# Altium schematic sheet Airlock_BTLE.SchDoc(Airlock_BTLE)
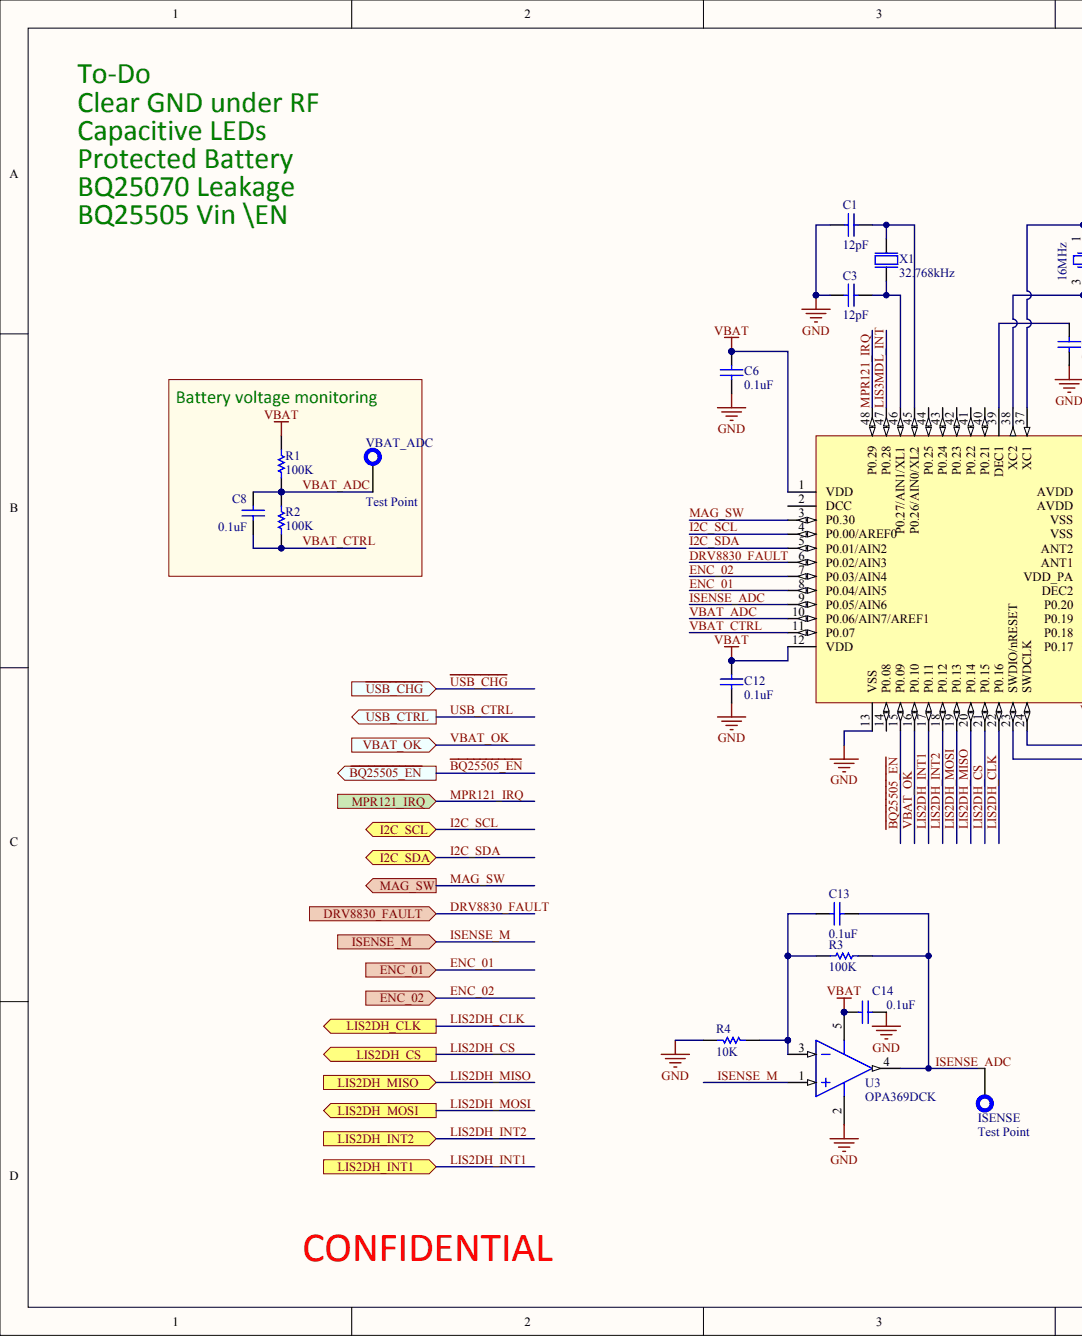
[diagram p0: Airlock_BTLE.SchDoc, left]
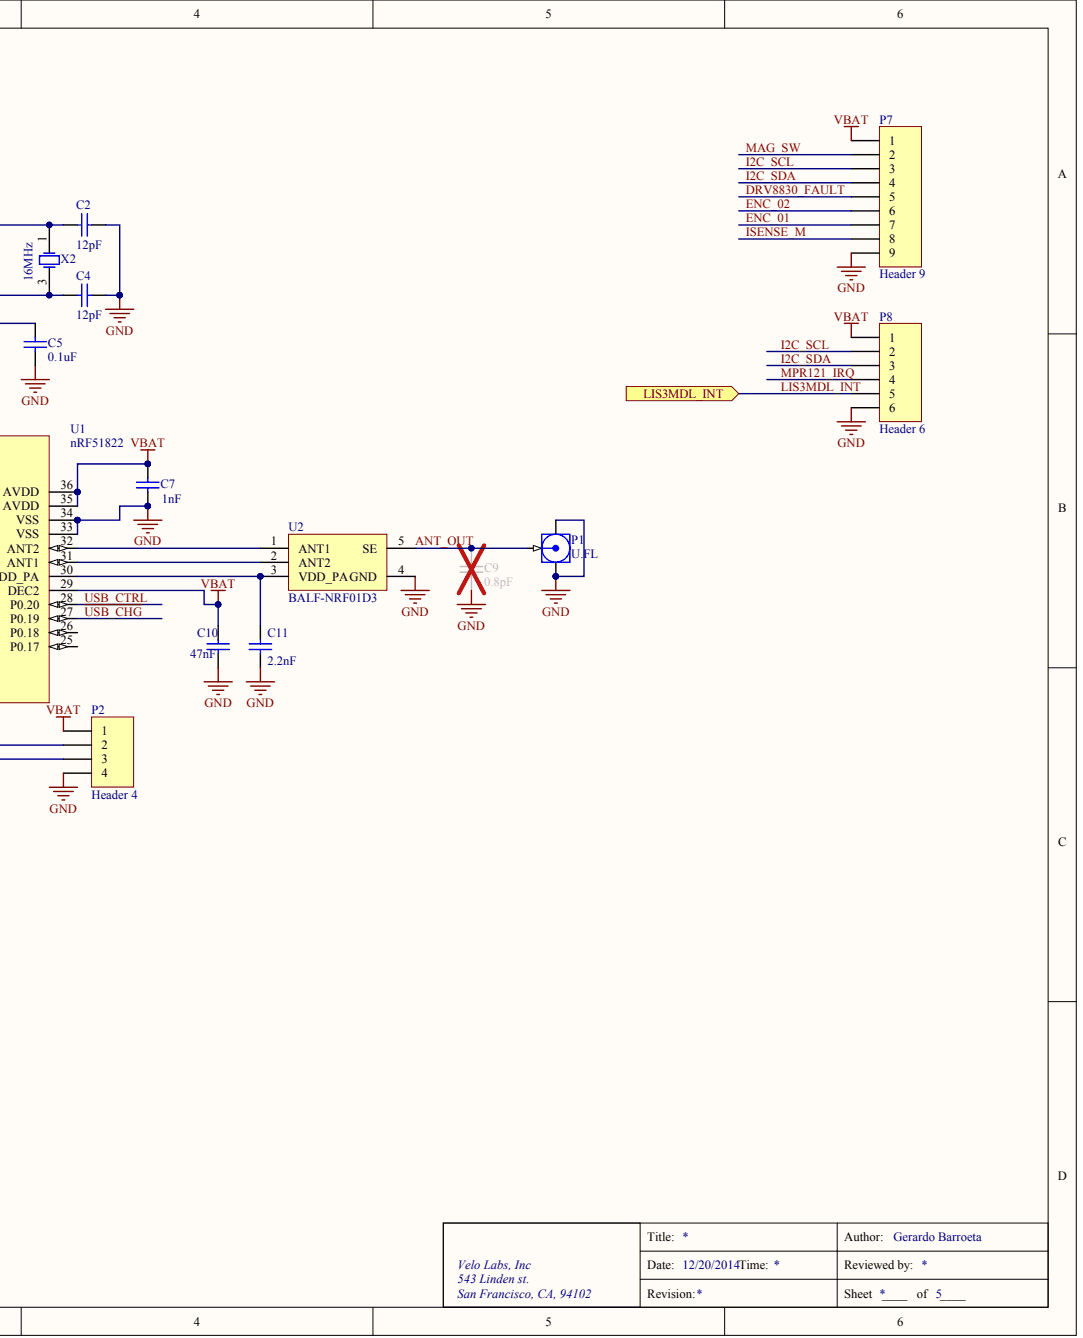
[diagram p1: Airlock_BTLE.SchDoc, right]

## Parts
C1  value 12pF  (hidden comment: 1% NP0, 50V)
C2  value 12pF  (hidden comment: 1% NP0, 50V)
C3  value 12pF  (hidden comment: 1% NP0, 50V)
C4  value 12pF  (hidden comment: 1% NP0, 50V)
C5  value 0.1uF  (hidden comment: X7R, 16V, 10%)
C6  value 0.1uF  (hidden comment: X7R, 16V, 10%)
C7  value 1nF  (hidden comment: 1%, NP0, 50V)
C8  value 0.1uF  (hidden comment: X7R, 16V, 10%)
C9  value 0.8pF  (hidden comment: Cap)
C10  value 47nF  (hidden comment: 10%, X7R, 25V)
C11  value 2.2nF  (hidden comment: X7R, 50V, 10%)
C12  value 0.1uF  (hidden comment: X7R, 16V, 10%)
C13  value 0.1uF  (hidden comment: X7R, 16V, 10%)
C14  value 0.1uF  (hidden comment: X7R, 16V, 10%)
ISENSE  Test Point
P1  U.FL
P2  Header 4
P7  Header 9
P8  Header 6
R1  value 100K  (hidden comment: 5% 1/10W)
R2  value 100K  (hidden comment: 5% 1/10W)
R3  value 100K  (hidden comment: 5% 1/10W)
R4  1%  value 10K
U1  nRF51822
U2  BALF-NRF01D3
U3  OPA369DCK
VBAT_ADC  Test Point
X1  32.768kHz
X2  Load Capacitance: 12pF  (hidden comment: 16MHz)

## Ports
DRV8830_FAULT, ENC_01, ENC_02, I2C_SCL, I2C_SDA, ISENSE_M, LIS2DH_CLK, LIS2DH_CS, LIS2DH_INT1, LIS2DH_INT2, LIS2DH_MISO, LIS2DH_MOSI, LIS3MDL_INT, MAG_SW, MPR121_IRQ, USB_CTRL, VBAT_OK, ~{BQ25505_EN}, ~{USB_CHG}

## Nets
GND: C1.1 C2.1 C3.1 C4.1 C5.1 C6.1 C7.1 C9.1 C10.1 C11.1 C12.1 C14.2 P1.2 P1.3 P2.4 P7.9 P8.6 R4.2 U1.13(VSS) U1.33(VSS) U1.34(VSS) U1.49 U2.4(GND) U3.2 X2.2 X2.4
VBAT: C6.2 C7.2 C10.2 C12.2 C14.1 P2.1 P7.1 P8.1 R1.2 U1.1(VDD) U1.12(VDD) U1.29(DEC2) U1.35(AVDD) U1.36(AVDD) U3.5
~{BQ25505_EN}: U1.15(P0.09) ; port ~{BQ25505_EN}
~{USB_CHG}: U1.27(P0.19) ; port ~{USB_CHG}
ANT_OUT: C9.2 P1.1 U2.5(SE)
DRV8830_FAULT: P7.5 U1.6(P0.02/AIN3) ; port DRV8830_FAULT
ENC_01: P7.7 U1.8(P0.04/AIN5) ; port ENC_01
ENC_02: P7.6 U1.7(P0.03/AIN4) ; port ENC_02
I2C_SCL: P7.3 P8.2 U1.4(P0.00/AREF0) ; port I2C_SCL
I2C_SDA: P7.4 P8.3 U1.5(P0.01/AIN2) ; port I2C_SDA
ISENSE_ADC: C13.2 ISENSE.1 R3.1 U1.9(P0.05/AIN6) U3.4
ISENSE_M: P7.8 U3.1 ; port ISENSE_M
LIS2DH_CLK: U1.22(P0.16) ; port LIS2DH_CLK
LIS2DH_CS: U1.21(P0.15) ; port LIS2DH_CS
LIS2DH_INT1: U1.17(P0.11) ; port LIS2DH_INT1
LIS2DH_INT2: U1.18(P0.12) ; port LIS2DH_INT2
LIS2DH_MISO: U1.20(P0.14) ; port LIS2DH_MISO
LIS2DH_MOSI: U1.19(P0.13) ; port LIS2DH_MOSI
LIS3MDL_INT: P8.5 U1.47(P0.28) ; port LIS3MDL_INT
MAG_SW: P7.2 U1.3(P0.30) ; port MAG_SW
MPR121_IRQ: P8.4 U1.48(P0.29) ; port MPR121_IRQ
USB_CTRL: U1.28(P0.20) ; port USB_CTRL
VBAT_ADC: C8.2 R1.1 R2.2 U1.10(P0.06/AIN7/AREF1) VBAT_ADC.1
VBAT_CTRL: C8.1 R2.1 U1.11(P0.07)
VBAT_OK: U1.16(P0.10) ; port VBAT_OK
NetC1_2: C1.2 U1.45(P0.26/AIN0/XL2) X1.1
NetC2_2: C2.2 U1.37(XC1) X2.1
NetC3_2: C3.2 U1.46(P0.27/AIN1/XL1) X1.2
NetC4_2: C4.2 U1.38(XC2) X2.3
NetC5_2: C5.2 U1.39(DEC1)
NetC11_2: C11.2 U1.30(VDD_PA) U2.3(VDD_PA)
NetC13_1: C13.1 R3.2 R4.1 U3.3
NetP2_2: P2.2 U1.24(SWDCLK)
NetP2_3: P2.3 U1.23(SWDIO/nRESET)
NetU1_31: U1.31(ANT1) U2.2(ANT2)
NetU1_32: U1.32(ANT2) U2.1(ANT1)

Not connected: U1 2(DCC) 14(P0.08) 25(P0.17) 26(P0.18) 40(P0.21) 41(P0.22) 42(P0.23) 43(P0.24) 44(P0.25)

## Library data of the parts
C1 C3 C4 = (no symbol name): Manufacturer: Murata Electronics North America; Manufacturer Part Number: GRM1555C1H120FA01D; Description 1: CAP CER 12PF 50V 1% NP0 0402; Temperature Coefficient: C0G, NP0; Supplier 1: Digi-Key; Supplier Part Number 1: 490-6196-1-ND; RoHS: RoHS Compliant; Category: Capacitors; Stock 1: 92208; Pricing 1: 1=0.1, 10=0.054, 50=0.0292, 100=0.0247, 250=0.0202, 500=0.01728, 1000=0.0132, 5000=0.011 (USD); Packaging: Cut Tape (CT); Capacitance: 12pF; Voltage - Rated: 50V; Tolerance: ±1%; Mounting Type: Surface Mount, MLCC; Operating Temperature: -55°C ~ 125°C; Applications: General Purpose; Ratings: -; Package / Case: 0402 (1005 Metric); Size / Dimension: 0.039" L x 0.020" W (1.00mm x 0.50mm); Height - Seated (Max): -; Thickness (Max): 0.022" (0.55mm); Lead Spacing: -; Features: -; Lead Style: -; ComponentLink1URL: http://search.murata.co.jp/Ceramy/image/img/A01X/G101/ENG/GRM1555C1H120FA01-01.pdf; ComponentLink1Description: http://search.murata.co.jp/Ceramy/image/img/A01X/G101/ENG/GRM1555C1H120FA01-01.pdf
C2 = (no symbol name): Manufacturer: Murata Electronics North America; Manufacturer Part Number: GRM1555C1H120FA01D; Value: 12pF; Description 1: CAP CER 12PF 50V 1% NP0 0402; Temperature Coefficient: C0G, NP0; Supplier 1: Digi-Key; Supplier Part Number 1: 490-6196-1-ND; RoHS: RoHS Compliant; Category: Capacitors; Stock 1: 92208; Pricing 1: 1=0.1, 10=0.054, 50=0.0292, 100=0.0247, 250=0.0202, 500=0.01728, 1000=0.0132, 5000=0.011 (USD); Packaging: Cut Tape (CT); Capacitance: 12pF; Voltage - Rated: 50V; Tolerance: ±1%; Mounting Type: Surface Mount, MLCC; Operating Temperature: -55°C ~ 125°C; Applications: General Purpose; Ratings: -; Package / Case: 0402 (1005 Metric); Size / Dimension: 0.039" L x 0.020" W (1.00mm x 0.50mm); Height - Seated (Max): -; Thickness (Max): 0.022" (0.55mm); Lead Spacing: -; Features: -; Lead Style: -; ComponentLink1URL: http://search.murata.co.jp/Ceramy/image/img/A01X/G101/ENG/GRM1555C1H120FA01-01.pdf; ComponentLink1Description: http://search.murata.co.jp/Ceramy/image/img/A01X/G101/ENG/GRM1555C1H120FA01-01.pdf
C5 C6 C8 C12-C14 = (no symbol name): Manufacturer: Murata Electronics North America; Manufacturer Part Number: GRM155R71C104KA88D; Description 1: CAP CER 0.1UF 16V 10% X7R 0402; Capacitance: 0.1µF; Supplier 1: Digi-Key; Supplier Part Number 1: 490-3261-1-ND; RoHS: RoHS Compliant; Category: Capacitors; Stock 1: 16410340; Pricing 1: 1=0.1, 10=0.015, 50=0.0082, 100=0.0069, 250=0.00564, 500=0.00482, 1000=0.00375, 5000=0.00313 (USD); Packaging: Cut Tape (CT); Tolerance: ±10%; Voltage - Rated: 16V; Temperature Coefficient: X7R; Mounting Type: Surface Mount, MLCC; Operating Temperature: -55°C ~ 125°C; Applications: General Purpose; Ratings: -; Package / Case: 0402 (1005 Metric); Size / Dimension: 0.039" L x 0.020" W (1.00mm x 0.50mm); Height - Seated (Max): -; Thickness (Max): 0.022" (0.55mm); Lead Spacing: -; Features: -; Lead Style: -; ComponentLink1URL: http://www.murata.com/~/media/webrenewal/support/library/catalog/products/capacitor/mlcc/c02e.ashx; ComponentLink1Description: http://www.murata.com/~/media/webrenewal/support/library/catalog/products/capacitor/mlcc/c02e.ashx
C7 = (no symbol name): Manufacturer: Murata Electronics North America; Manufacturer Part Number: GRM1555C1H102FA01D; Description 1: CAP CER 1000PF 50V 1% NP0 0402; Capacitance: 1000pF; Temperature Coefficient: C0G, NP0; Published: 8-Jun-2000; LatestRevisionDate: 17-Jul-2002; LatestRevisionNote: Re-released for DXP Platform.; PackageReference: RAD-0.3; PackageDescription: Radial Cap, Thru-Hole; 2 Leads; 0.3 in Pin Spacing; Supplier 1: Digi-Key; Supplier Part Number 1: 490-6189-1-ND; RoHS: RoHS Compliant; Category: Capacitors; Stock 1: 28524; Pricing 1: 1=0.22, 10=0.147, 50=0.089, 100=0.0748, 250=0.06052, 500=0.0534, 1000=0.04539, 5000=0.03827 (USD); Packaging: Cut Tape (CT); Voltage - Rated: 50V; Tolerance: ±1%; Mounting Type: Surface Mount, MLCC; Operating Temperature: -55°C ~ 125°C; Applications: General Purpose; Ratings: -; Package / Case: 0402 (1005 Metric); Size / Dimension: 0.039" L x 0.020" W (1.00mm x 0.50mm); Height - Seated (Max): -; Thickness (Max): 0.022" (0.55mm); Lead Spacing: -; Features: -; Lead Style: -; ComponentLink1URL: http://search.murata.co.jp/Ceramy/image/img/A01X/G101/ENG/GRM1555C1H102FA01-01.pdf; ComponentLink1Description: http://search.murata.co.jp/Ceramy/image/img/A01X/G101/ENG/GRM1555C1H102FA01-01.pdf; Publisher: Altium Limited
C10 = (no symbol name): Manufacturer: Murata Electronics North America; Manufacturer Part Number: GRM155R71E473KA88D; Description 1: CAP CER 0.047UF 25V 10% X7R 0402; Capacitance: 0.047µF; Published: 8-Jun-2000; LatestRevisionDate: 17-Jul-2002; LatestRevisionNote: Re-released for DXP Platform.; PackageReference: RAD-0.3; PackageDescription: Radial Cap, Thru-Hole; 2 Leads; 0.3 in Pin Spacing; Supplier 1: Digi-Key; Supplier Part Number 1: 490-3254-2-ND; RoHS: RoHS Compliant; Category: Capacitors; Stock 1: 110000; Pricing 1: 10000=0.0051, 20000=0.00486, 30000=0.00462, 50000=0.00413, 100000=0.00334 (USD); Packaging: Tape & Reel (TR); Voltage - Rated: 25V; Tolerance: ±10%; Temperature Coefficient: X7R; Mounting Type: Surface Mount, MLCC; Operating Temperature: -55°C ~ 125°C; Applications: General Purpose; Ratings: -; Package / Case: 0402 (1005 Metric); Size / Dimension: 0.039" L x 0.020" W (1.00mm x 0.50mm); Height - Seated (Max): -; Thickness (Max): 0.022" (0.55mm); Lead Spacing: -; Features: -; Lead Style: -; ComponentLink1URL: http://www.murata.com/products/catalog/pdf/c02e.pdf; ComponentLink1Description: http://www.murata.com/products/catalog/pdf/c02e.pdf; Publisher: Altium Limited
C11 = (no symbol name): Manufacturer: Murata Electronics North America; Manufacturer Part Number: GRM155R71H222KA01D; Description 1: CAP CER 2200PF 50V 10% X7R 0402; Capacitance: 2200pF; Published: 8-Jun-2000; LatestRevisionDate: 29-May-2009; LatestRevisionNote: IPC-7351 Footprint Added.; PackageReference: C1206; PackageDescription: Chip Capacitor; Supplier 1: Digi-Key; Supplier Part Number 1: 490-1305-1-ND; RoHS: RoHS Compliant; Category: Capacitors; Stock 1: 342279; Pricing 1: 1=0.1, 10=0.021, 50=0.0114, 100=0.0096, 250=0.00788, 500=0.00674, 1000=0.00525, 5000=0.00438 (USD); Packaging: Cut Tape (CT); Voltage - Rated: 50V; Tolerance: ±10%; Temperature Coefficient: X7R; Mounting Type: Surface Mount, MLCC; Operating Temperature: -55°C ~ 125°C; Applications: General Purpose; Ratings: -; Package / Case: 0402 (1005 Metric); Size / Dimension: 0.039" L x 0.020" W (1.00mm x 0.50mm); Height - Seated (Max): -; Thickness (Max): 0.022" (0.55mm); Lead Spacing: -; Features: -; Lead Style: -; ComponentLink1URL: http://www.murata.com/products/catalog/pdf/c02e.pdf; ComponentLink1Description: http://www.murata.com/products/catalog/pdf/c02e.pdf; Publisher: Altium Limited
P1 = (no symbol name): Manufacturer: Hirose Electric Co Ltd; Manufacturer Part Number: U.FL-R-SMT(10); Description 1: CONN UMC JACK STR 50 OHM SMD; Connector Style: Ultra Miniature Coaxial; Connector Type: Jack, Male Pin; Contact Termination: Solder; Impedance: 50 Ohm; Frequency - Max: 6GHz; Body Material: Phosphor Bronze; Body Finish: Silver; Center Contact Plating: Gold; Dielectric Material: Liquid Crystal Polymer (LCP); Supplier 1: Digi-Key; RoHS: RoHS Compliant; Category: Connectors, Interconnects; Stock 1: 135430; Pricing 1: 1=1.31, 10=1.15, 25=1.0824, 50=1.0374, 100=0.9922, 250=0.902, 500=0.8118, 1000=0.7216 (USD); Packaging: Cut Tape (CT); Shield Termination: Solder; Mounting Type: Surface Mount; Cable Group: -; Fastening Type: Snap-On; Features: -; Housing Color: Silver; Ingress Protection: -; Center Contact Material: Phosphor Bronze; Voltage Rating: -; Operating Temperature: -40°C ~ 90°C; ComponentLink1URL: http://www.hirose.co.jp/cataloge_hp/e32119372.pdf; ComponentLink1Description: http://www.hirose.co.jp/cataloge_hp/e32119372.pdf; Supplier Part Number 1: H9161CT-ND
R1-R3 = (no symbol name): Manufacturer: Panasonic Electronic Components; Manufacturer Part Number: ERJ-2GEJ104X; Description 1: RES 100K OHM 1/10W 5% 0402 SMD; Power (Watts): 0.1W, 1/10W; Temperature Coefficient: ±200ppm/°C; Published: 8-Jun-2000; LatestRevisionDate: 17-Jul-2002; LatestRevisionNote: Re-released for DXP Platform.; PackageReference: AXIAL-0.3; PackageDescription: Axial Device, Thru-Hole; 2 Leads; 0.3 in Pin Spacing; Supplier 1: Digi-Key; Supplier Part Number 1: P100KJCT-ND; RoHS: RoHS Compliant; Category: Resistors; Stock 1: 539799; Pricing 1: 1=0.1, 50=0.0172, 100=0.0131, 250=0.00976, 500=0.0072, 1000=0.0048, 5000=0.00338 (USD); Packaging: Cut Tape (CT); Resistance (Ohms): 100k; Tolerance: ±5%; Composition: Thick Film; Features: -; Package / Case: 0402 (1005 Metric); Supplier Device Package: 0402; Size / Dimension: 0.039" L x 0.020" W (1.00mm x 0.50mm); Height: 0.016" (0.40mm); Number of Terminations: 2; ComponentLink1URL: http://industrial.panasonic.com/www-cgi/jvcr13pz.cgi?E+PZ+3+AOA0001+ERJ2GEJ104X+7+WW; ComponentLink1Description: http://industrial.panasonic.com/www-cgi/jvcr13pz.cgi?E+PZ+3+AOA0001+ERJ2GEJ104X+7+WW; Publisher: Altium Limited
R4 = (no symbol name): Manufacturer: Panasonic Electronic Components; Manufacturer Part Number: ERJ-2RKF1002X; Description 1: RES SMD 10K OHM 1% 1/10W 0402; Power (Watts): 0.1W, 1/10W; Temperature Coefficient: ±100ppm/°C; Published: 8-Jun-2000; LatestRevisionDate: 17-Jul-2002; LatestRevisionNote: Re-released for DXP Platform.; PackageReference: AXIAL-0.3; PackageDescription: Axial Device, Thru-Hole; 2 Leads; 0.3 in Pin Spacing; Supplier 1: Digi-Key; Supplier Part Number 1: P10.0KLCT-ND; RoHS: RoHS Compliant; Category: Resistors; Stock 1: 185308; Pricing 1: 1=0.1, 50=0.0196, 100=0.0149, 250=0.01104, 500=0.00816, 1000=0.00544, 5000=0.00383 (USD); Packaging: Cut Tape (CT); Resistance (Ohms): 10k; Tolerance: ±1%; Composition: Thick Film; Features: Automotive AEC-Q200 Qualified; Operating Temperature: -55°C ~ 155°C; Package / Case: 0402 (1005 Metric); Supplier Device Package: 0402; Size / Dimension: 0.039" L x 0.020" W (1.00mm x 0.50mm); Height: 0.016" (0.40mm); Number of Terminations: 2; ComponentLink1URL: http://industrial.panasonic.com/www-cgi/jvcr13pz.cgi?E+PZ+3+AOA0002+ERJ2RKF1002X+7+WW; ComponentLink1Description: http://industrial.panasonic.com/www-cgi/jvcr13pz.cgi?E+PZ+3+AOA0002+ERJ2RKF1002X+7+WW; Publisher: Altium Limited
U1 = (no symbol name): Manufacturer: Nordic Semiconductor ASA; Manufacturer Part Number: NRF51822-QFAA-T; Frequency: 2.4GHz; Data Rate (Max): 2Mbps; Modulation or Protocol: Bluetooth v4.0, Low Energy; Power - Output: 4dBm; Sensitivity: -96dBm; Voltage - Supply: 1.8 V ~ 3.6 V; Current - Receiving: 13.4mA; Current - Transmitting: 16mA; Data Interface: PCB, Surface Mount; Memory Size: 256kB Flash, 16kB RAM; Description 1: IC SOC 2.4GHZ 256K FLASH 48QFN; Supplier 1: Digi-Key; RoHS: RoHS Compliant; Category: RF/IF and RFID; Stock 1: 617; Pricing 1: 1=4.62, 10=4.125, 50=3.7126, 100=3.3825, 250=3.05252, 500=2.739, 1000=2.31, 2500=2.1945, 5000=2.10375 (USD); Packaging: Tray; Applications: General Purpose; Antenna Connector: PCB, Surface Mount; Operating Temperature: -25°C ~ 75°C; Package / Case: 48-VFQFN Exposed Pad; ComponentLink1URL: http://www.nordicsemi.com/eng/nordic/download_resource/20351/6/38628533; ComponentLink1Description: http://www.nordicsemi.com/eng/nordic/download_resource/20351/6/38628533; Supplier Part Number 1: 1490-1024-ND
U2 = (no symbol name): Manufacturer: STMicroelectronics; Description 1: BALUN ULTRAMINI 5FLIPCHIP; Supplier 1: Digi-Key; Manufacturer Part Number: BALF-NRF01D3; RoHS: RoHS Compliant; Category: RF/IF and RFID; Stock 1: 1509; Pricing 1: 1=0.67, 10=0.569, 25=0.498, 100=0.4264, 250=0.36984, 500=0.31326, 1000=0.2415, 2500=0.2208 (USD); ComponentLink1URL: http://www.st.com/st-web-ui/static/active/en/resource/technical/document/datasheet/DM00109732.pdf; ComponentLink1Description: http://www.st.com/st-web-ui/static/active/en/resource/technical/document/datasheet/DM00109732.pdf; Supplier Part Number 1: 497-14944-1-ND
U3 = (no symbol name): Manufacturer: Texas Instruments; Manufacturer Part Number: OPA369AIDCKR; Description 1: IC OPAMP RRIO 1.8V SGL SC70-5; Amplifier Type: General Purpose; Number of Circuits: 1; Output Type: Rail-to-Rail; Slew Rate: 0.005 V/µs; Gain Bandwidth Product: 12kHz; Current - Input Bias: 10pA; Voltage - Input Offset: 250µV; Current - Supply: 0.8µA; Current - Output / Channel: 10mA; Voltage - Supply, Single/Dual (±): 1.8 V ~ 5.5 V; Supplier 1: Digi-Key; RoHS: RoHS Compliant; Category: Integrated Circuits (ICs); Stock 1: 10239; Pricing 1: 1=1.87, 10=1.685, 25=1.5092, 100=0.7898, 250=0.77512, 500=0.7605, 750=0.74588 (USD); -3db Bandwidth: -; Operating Temperature: -40°C ~ 85°C; Mounting Type: Surface Mount; Package / Case: 6-TSSOP (5 Lead), SC-88A, SOT-353; Supplier Device Package: SC-70-5; Packaging: Cut Tape (CT); ComponentLink1URL: http://www.ti.com/lit/ds/symlink/opa369.pdf; ComponentLink1Description: http://www.ti.com/lit/ds/symlink/opa369.pdf; Supplier Part Number 1: 296-25252-1-ND
X1 = (no symbol name): Manufacturer: Epson Timing; Manufacturer Part Number: FC-135 32.7680KA-AC; Description 1: Crystals 32.768KHz 9pF 20ppm; Load Capacitance: 9 pF; Tolerance: 20 PPM; ESR: 65 kOhms; Case Height: 0.8 mm; Case Length: 3.2 mm; Case Width: 1.5 mm; Value: 32.768kHz; Supplier 1: Mouser; Supplier Part Number 1: 732-FC-13532.768KAAC; RoHS: RoHS Compliant By Exemption; Category: Crystals; Stock 1: 124; Pricing 1: 1=1.57, 10=1.22, 100=1.15, 500=1.03, 1000=0.910, 2500=0.880, 5000=0.849, 10000=0.819 (AUD); Dimensions: 1.5 mm W x 3.2 mm L x 0.8 mm H; Frequency: 32.768 kHz; Operating Temperature Range: - 40 C to + 85 C; Packaging: Bulk; Series: FC-135R/FC-135/FC-255; Termination Style: SMD/SMT; Type: kHz Range Crystal Unit; Brand: Epson Timing; Mounting Style: SMD/SMT; RoHS - Mouser: E; Minimum Order Quantity: 1; Reeling: False; ComponentLink1URL: http://www.mouser.com/ds/2/137/1699449-465441.pdf; ComponentLink1Description: http://www.mouser.com/ds/2/137/1699449-465441.pdf
X2 = (no symbol name): Manufacturer: NDK; Manufacturer Part Number: NX2520SA-16MHZ-EXS00A-CS05116; Description 1: CRYSTAL 16MHZ 12PF SMD; Frequency Stability: ±15ppm; ESR (Equivalent Series Resistance): 80 Ohm; Operating Mode: Fundamental; Supplier 1: Digi-Key; RoHS: RoHS Compliant; Category: Crystals and Oscillators; Stock 1: 3775; Pricing 1: 1=1.45, 10=1.384, 50=1.3534, 100=1.1574, 500=1.12674, 1000=0.94916 (USD); Packaging: Cut Tape (CT); Type: MHz Crystal; Frequency: 16MHz; Frequency Tolerance: ±15ppm; Operating Temperature: -10°C ~ 75°C; Ratings: -; Mounting Type: Surface Mount; Package / Case: 4-SMD, No Lead (DFN, LCC); Size / Dimension: 0.098" L x 0.079" W (2.50mm x 2.00mm); Height: 0.022" (0.55mm); ComponentLink1URL: http://media.digikey.com/pdf/Data%20Sheets/NDK%20PDFs/NX2520SA-16MHZ-EXS00A-CS05116.pdf; ComponentLink1Description: http://media.digikey.com/pdf/Data%20Sheets/NDK%20PDFs/NX2520SA-16MHZ-EXS00A-CS05116.pdf; Supplier Part Number 1: 644-1200-1-ND; Value: 16MHz
C9 ISENSE P2 P7 P8 VBAT_ADC = (no symbol name)
  C9: Published: 8-Jun-2000; LatestRevisionDate: 17-Jul-2002; LatestRevisionNote: Re-released for DXP Platform.; PackageReference: RAD-0.3; PackageDescription: Radial Cap, Thru-Hole; 2 Leads; 0.3 in Pin Spacing
  P2 P7 P8: LatestRevisionDate: 17-Jul-2002; LatestRevisionNote: Re-released for DXP Platform.
  C9 P2 P7 P8: Publisher: Altium Limited
